# Altium schematic sheet Serial Monitor CAN Module Schematic.SchDoc("Serial Monitor CAN Module Schematic")
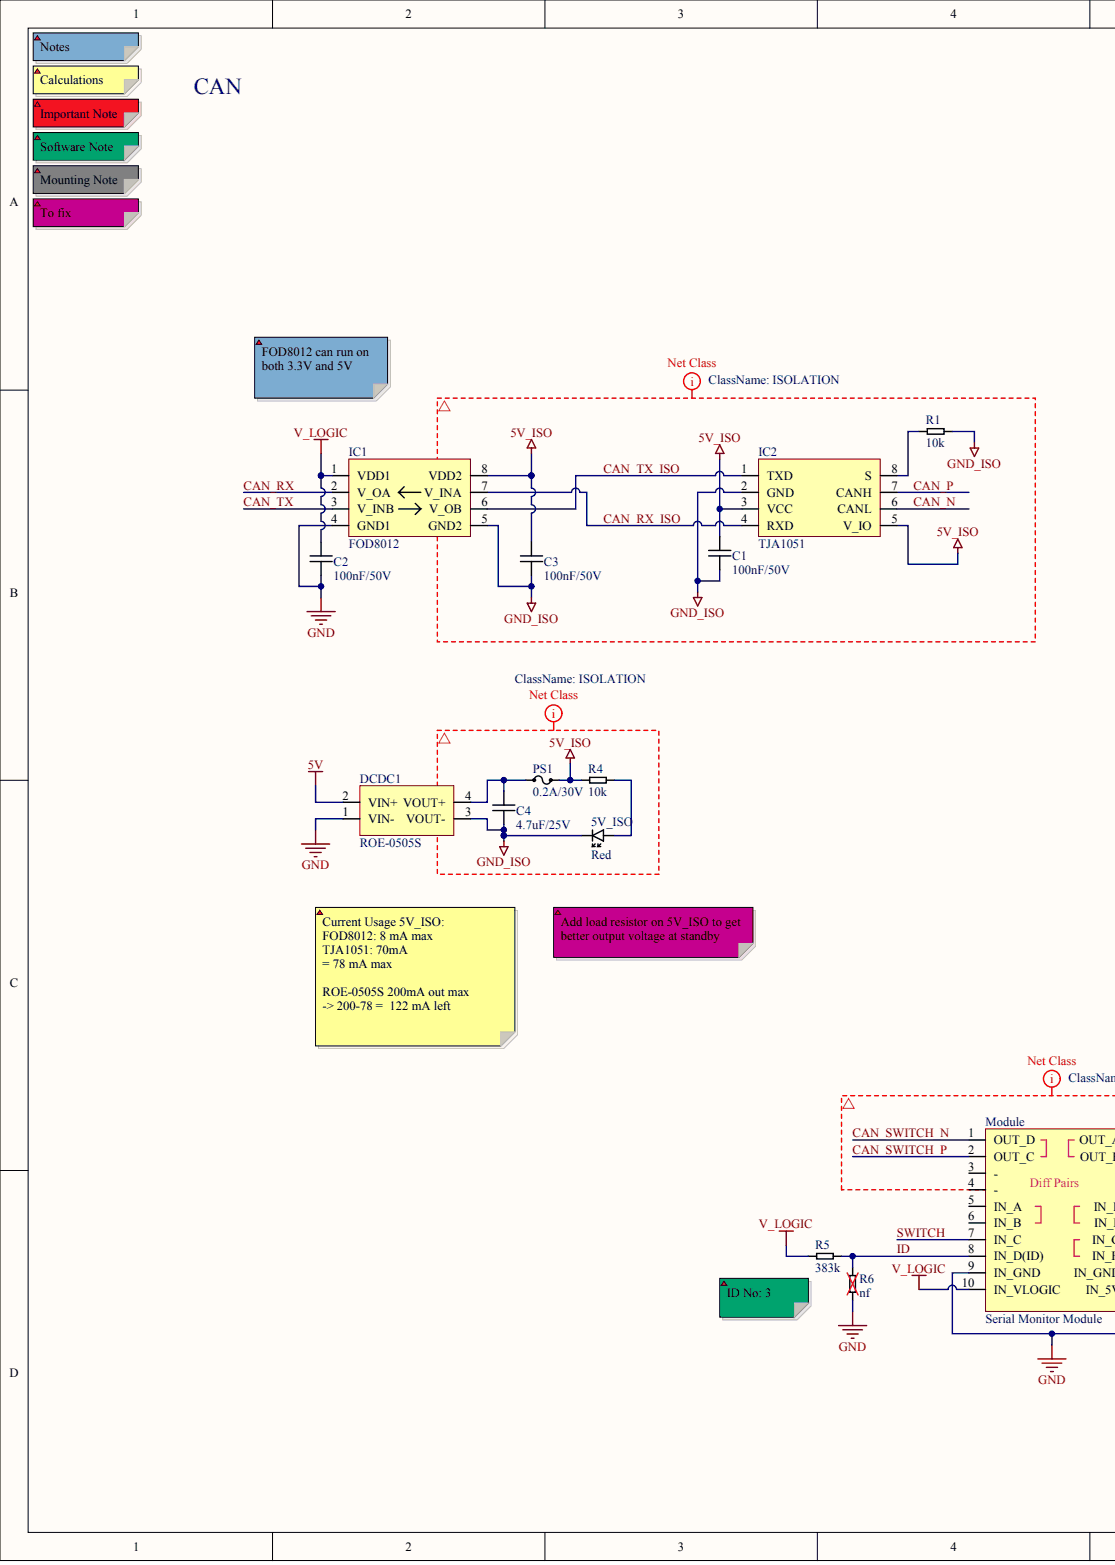
[diagram p0: Serial Monitor CAN Module Schematic.SchDoc, left]
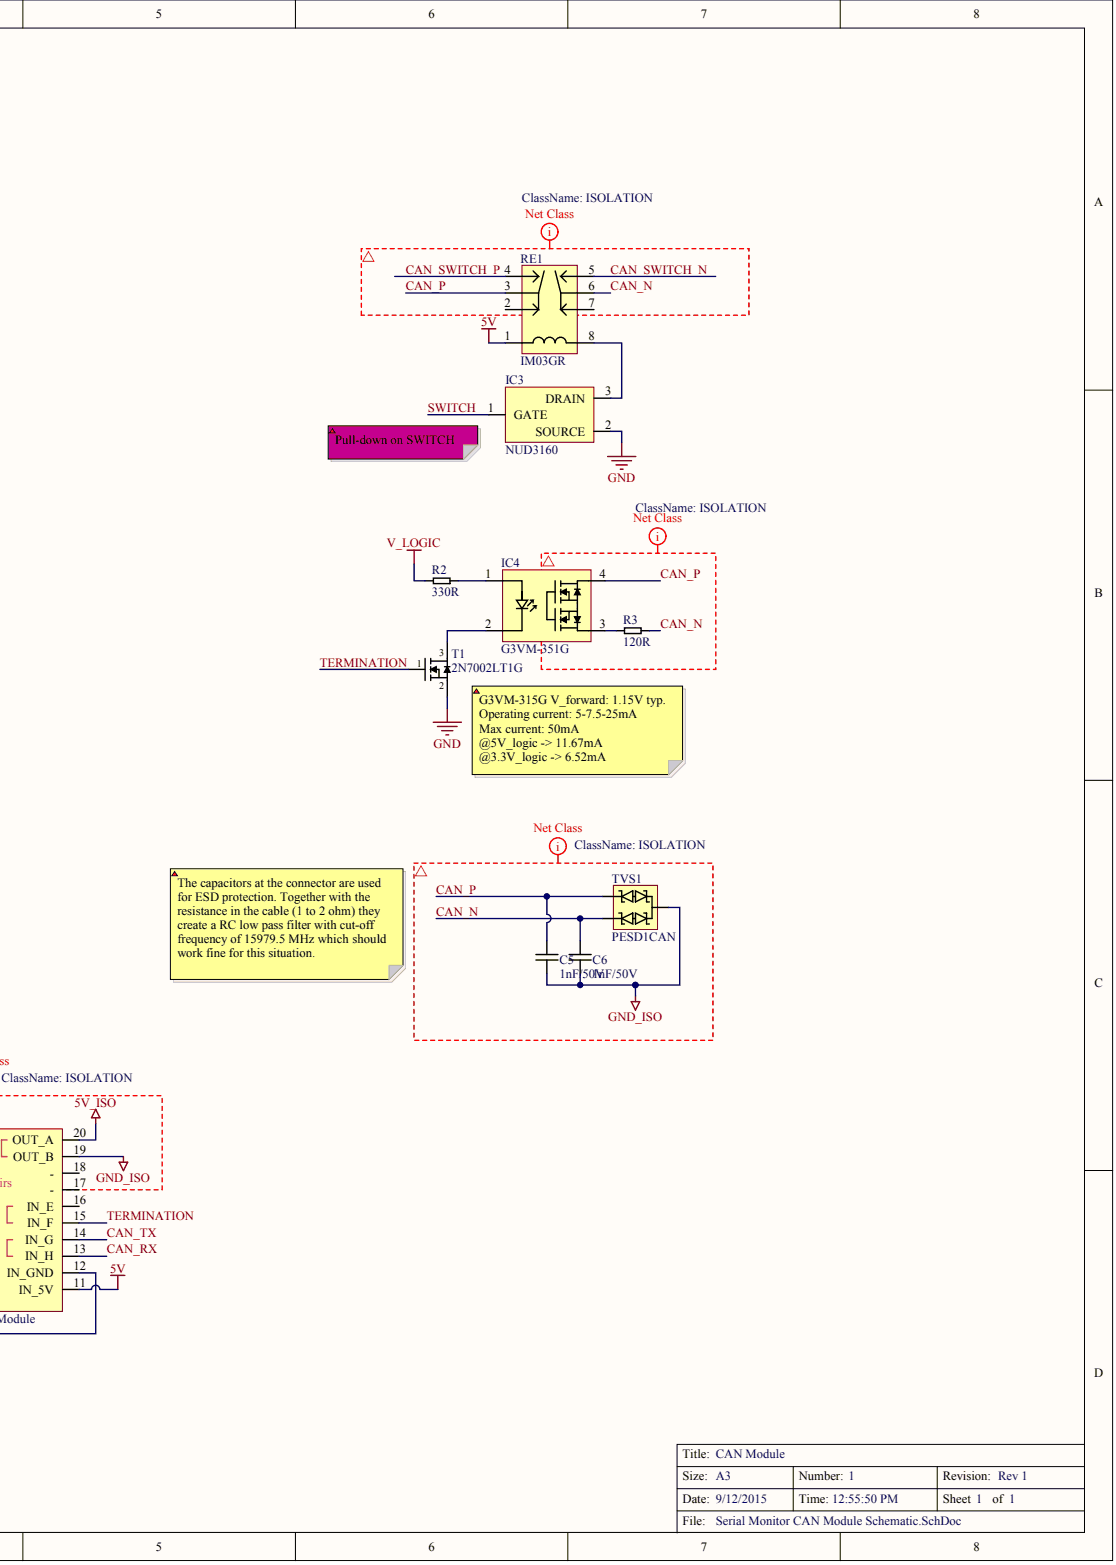
[diagram p1: Serial Monitor CAN Module Schematic.SchDoc, right]

## Parts
5V_ISO  value Red  (hidden comment: LED_0603_Red)
C1  value 100nF/50V  [0603]  (hidden comment: Cap_0603_100nF/50V_X7R)
C2  value 100nF/50V  [0603]  (hidden comment: Cap_0603_100nF/50V_X7R)
C3  value 100nF/50V  [0603]  (hidden comment: Cap_0603_100nF/50V_X7R)
C4  value 4.7uF/25V  [0805]  (hidden comment: Cap_0805_4.7uF/25V)
C5  value 1nF/50V  [0603]  (hidden comment: Cap_0603_1nF/50V)
C6  value 1nF/50V  [0603]  (hidden comment: Cap_0603_1nF/50V)
DCDC1  ROE-0505S  [4-SIP]
IC1  FOD8012
IC2  TJA1051
IC3  NUD3160
IC4  G3VM-351G
Module  Serial Monitor Module
PS1  value 0.2A/30V  [SMD 1206]  (hidden comment: Polyswitch_1206_0.2Ahold_30V)
R1  value 10k  [0603]  (hidden comment: Res_0603_10k)
R2  value 330R  [0603]  (hidden comment: Res_0603_330R)
R3  value 120R  [0603]  (hidden comment: Res_0603_120R)
R4  value 10k  [0603]  (hidden comment: Res_0603_10k)
R5  value 383k  [0603]  (hidden comment: Res_0603_383k)
R6  value nf  [0603]  (hidden comment: Res_0603_nf)
RE1  value IM03GR  Part No.: IM03GR  (hidden comment: Relay IM03GR)
T1  2N7002LT1G  [SOT-23]
TVS1  PESD1CAN

## Nets
5V: DCDC1.2(VIN+) Module.11(IN_5V) RE1.1
5V_ISO: C1.2 C3.2 IC1.8(VDD2) IC2.3(VCC) IC2.5(V_IO) Module.20(OUT_A) PS1.2 R4.2
GND: C2.1 DCDC1.1(VIN-) IC1.4(GND1) IC3.2 Module.9(IN_GND) Module.12(IN_GND) R6.2 T1.2
GND_ISO: 5V_ISO.CATHODE C1.1 C3.1 C4.1 C5.1 C6.2 DCDC1.3(VOUT-) IC1.5(GND2) IC2.2(GND) Module.19(OUT_B) R1.1 TVS1.3
V_LOGIC: C2.2 IC1.1(VDD1) Module.10(IN_VLOGIC) R2.1 R5.2
CAN_N: C6.1 IC2.6(CANL) R3.1 RE1.6 TVS1.1
CAN_P: C5.2 IC2.7(CANH) IC4.4 RE1.3 TVS1.2
CAN_RX: IC1.2(V_OA) Module.13(IN_H)
CAN_RX_ISO: IC1.7(V_INA) IC2.4(RXD)
CAN_SWITCH_N: Module.1(OUT_D) RE1.5
CAN_SWITCH_P: Module.2(OUT_C) RE1.4
CAN_TX: IC1.3(V_INB) Module.14(IN_G)
CAN_TX_ISO: IC1.6(V_OB) IC2.1(TXD)
ID: Module.8(IN_D(ID)) R5.1 R6.1
SWITCH: IC3.1 Module.7(IN_C)
TERMINATION: Module.15(IN_F) T1.1
Net5V_ISO_ANODE: 5V_ISO.ANODE R4.1
NetC4_2: C4.2 DCDC1.4(VOUT+) PS1.1
NetIC2_8: IC2.8(S) R1.2
NetIC3_3: IC3.3 RE1.8
NetIC4_1: IC4.1 R2.2
NetIC4_2: IC4.2 T1.3
NetIC4_3: IC4.3 R3.2
N00030:
N00031:
N00032:
N00033:
N00034:
N00035:

Not connected: Module 3(-) 4(-) 5(IN_A) 6(IN_B) 16(IN_E) 17(-) 18(-); RE1 2 7

## Library data of the parts
5V_ISO = (no symbol name): Part No.: APT1608SRCPRV; Manufacturer: Kingbright; RS: 654-5420; DigiKey: 754-1122-1-ND; Price SEK (No VAT): 1; Mouser: 604-APT1608SRCPRV
C1-C3 = (no symbol name): Part No.: C1608X7R1H104K080AA; Manufacturer: TDK; RS: 741-4459 (500-pack); DigiKey: 445-1314-1-ND; Mouser: 810-C1608X7R1H104K; Price SEK (No VAT): 0,2
C4 = (no symbol name): Part No.: GRM21BR61E475KA12L; Manufacturer: Murata; DigiKey: 490-3335-1-ND; Mouser: 81-GRM21BR61E475KA12; Price SEK (No VAT): 1
C5 C6 = (no symbol name): Part No.: C1608X7R1H102K080AE; Manufacturer: TDK; Mouser: 810-C1608X7R1H102KAE; DigiKey: 445-15923-1-ND; Price SEK (No VAT): 0,4
DCDC1 = (no symbol name): Manufacturer: Recom Power; DigiKey: 945-1655-5-ND; Part No.: ROE-0505S; Price SEK (No VAT): 20; Mouser: 919-ROE-0505S; Value: ROE-0505S
IC1 = (no symbol name): Manufacturer: Fairchild Semiconductor; Note: Should be able to replace ADUM1201 as pin-compatible; DigiKey: FOD8012-ND; Part No.: FOD8012; Price SEK (No VAT): 31; Datasheet: http://www.fairchildsemi.com/ds/FO/FOD8012.pdf; Mouser: 512-FOD8012; Value: FOD8012
IC2 = (no symbol name): Part No.: TJA1051T/3,118; Manufacturer: NXP; DigiKey: 568-8684-1-ND; Price SEK (No VAT): 7; Datasheet: http://www.nxp.com/documents/data_sheet/TJA1051.pdf; Mouser: 771-TJA1051T3118; Value: TJA1051
IC3 = (no symbol name): Part No.: NUD3160LT1G; Manufacturer: ON; DigiKey: NUD3160LT1GOSCT-ND; Price SEK (No VAT): 5; Datasheet: http://www.onsemi.com/pub_link/Collateral/NUD3160-D.PDF; Mouser: 863-NUD3160LT1G; Value: NUD3160
IC4 = (no symbol name): Manufacturer: Omron; DigiKey: Z2084-ND; Part No.: G3VM-351G; Price SEK (No VAT): 23; Datasheet: http://www.omron.com/ecb/products/pdf/en-g3vm_351g.pdf; Mouser: 653-G3VM-351G; Value: G3VM-351G
PS1 = (no symbol name): Part No.: 0ZCJ0020FF2E; Manufacturer: Bel Fuse; Mouser: 530-0ZCJ0020FF2E; Price SEK (No VAT): 1; DigiKey: 507-1797-1-ND; RS: *; Farnell: *
R1 R4 = (no symbol name): Part No.: CRCW060310K0FKEA; Manufacturer: Vishay; Price SEK (No VAT): 0,1; Mouser: 71-CRCW0603-10K-E3; DigiKey: 541-10.0KHCT-ND
R2 = (no symbol name): Part No.: CRCW0603330RFKEA; Manufacturer: Vishay; Price SEK (No VAT): 0,1; Mouser: 71-CRCW0603-330-E3; DigiKey: 541-330HCT-ND
R3 = (no symbol name): Part No.: CRCW0603120RFKEA; Manufacturer: Vishay; Price SEK (No VAT): 0,1; DigiKey: 541-120HCT-ND; Mouser: 71-CRCW0603-120-E3
R5 = (no symbol name): Part No.: CRCW0603383KFKEA; Manufacturer: Vishay; DigiKey: 541-383KHCT-ND; Mouser: 71-CRCW0603-383K-E3; Price SEK (No VAT): 0,1
RE1 = (no symbol name): Manufacturer: TE; Mouser: 655-IM03GR; DigiKey: PB1061CT-ND; Price SEK (No VAT): 28; Datasheet: http://www.te.com/commerce/DocumentDelivery/DDEController?Action=srchrtrv&DocNm=108-98001&DocType=SS&DocLang=EN
T1 = (no symbol name): Manufacturer: ON Semiconductor; Mouser: 863-2N7002LT1G; Part No.: 2N7002LT1G; DigiKey: 2N7002LT1GOSCT-ND; Price SEK (No VAT): 2; Value: 2N7002LT1G
TVS1 = (no symbol name): Manufacturer: NXP; Part No.: PESD1CAN,215; Mouser: 771-PESD1CAN-T/R; DigiKey: 568-4032-1-ND; Price SEK (No VAT): 4; Value: PESD1CAN
Module R6 = (no symbol name)
  Module: Value: Serial Monitor Module
  R6: Price SEK (No VAT): 0
